# Altium schematic sheet eRatPlatform_1.SchDoc
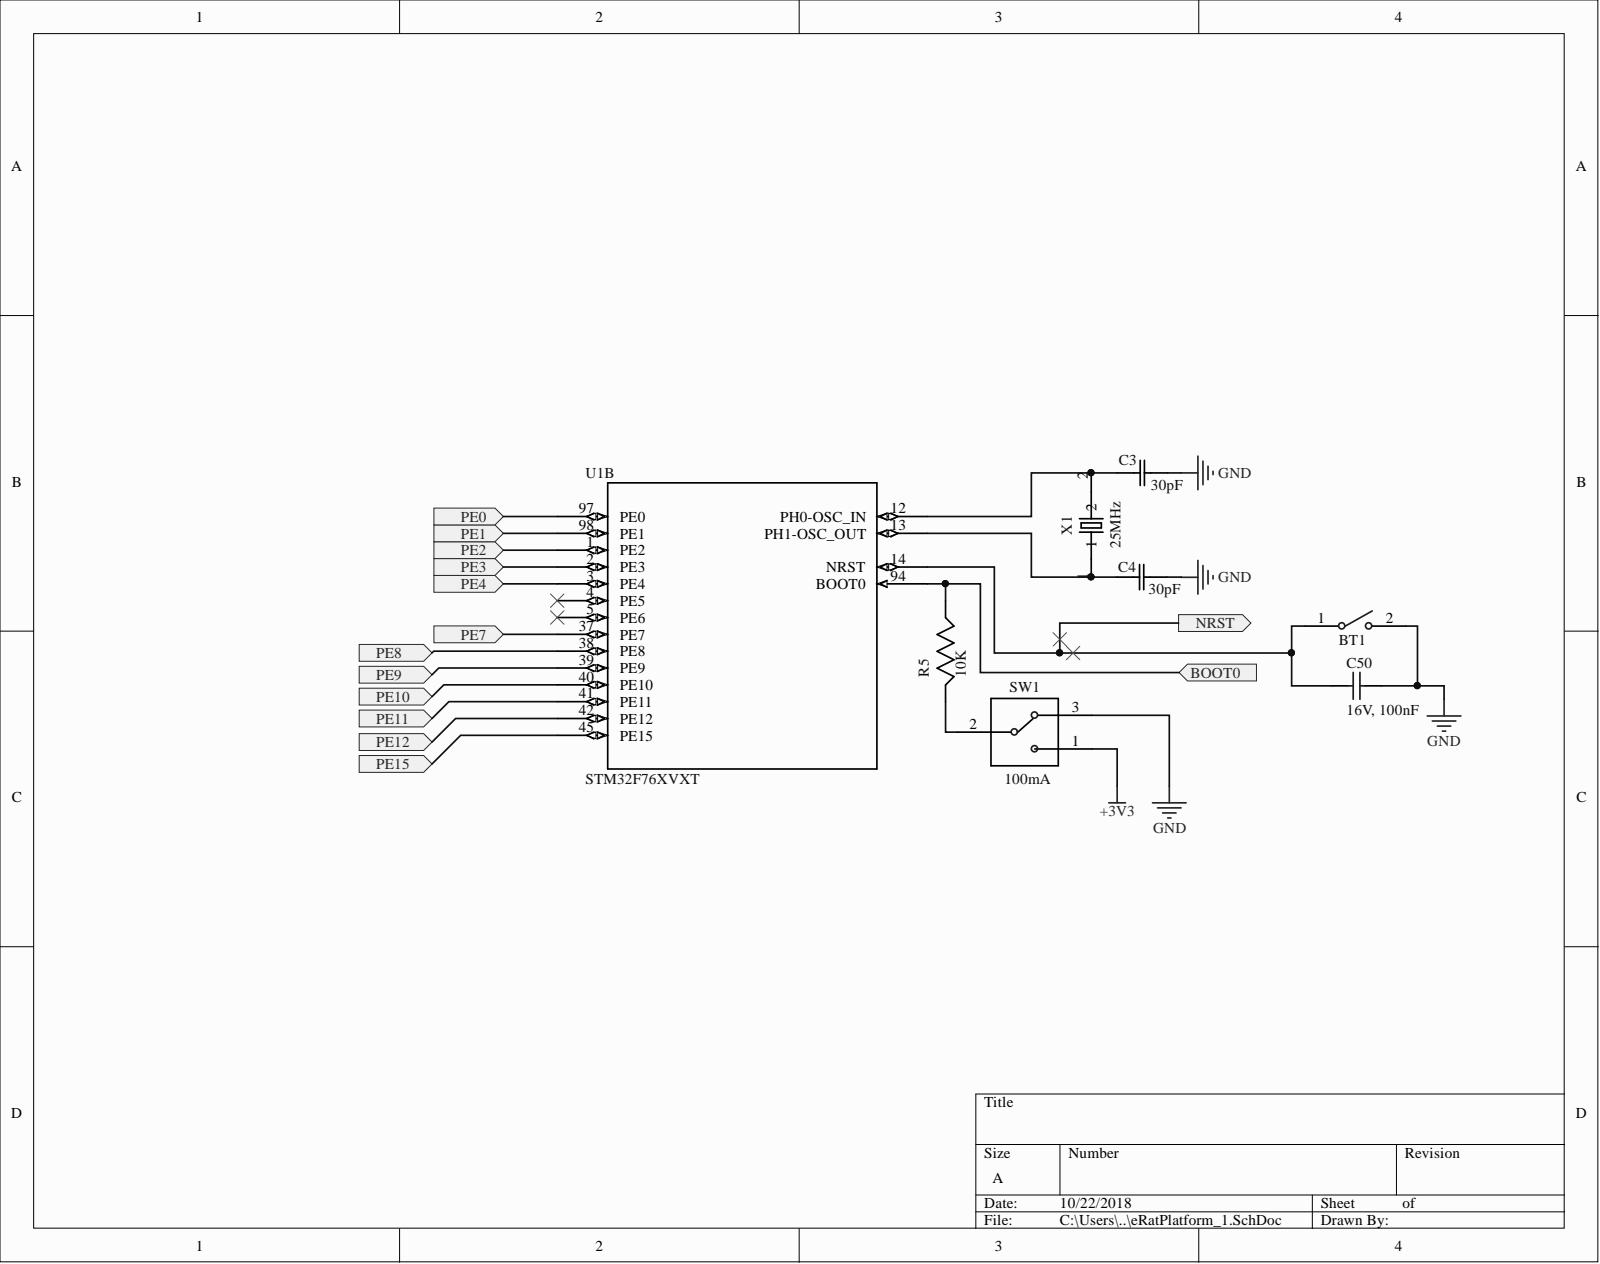
[diagram p0: eRatPlatform_1.SchDoc]

## Parts
BT1  434123050816  [434123050816]  (value not drawn)
C3  30pF  [CAPC1608X85N]
C4  30pF  [CAPC1608X85N]
C50  16V, 100nF  value 100nF  [1206_3.2x1.6x0.8mm]
R5  10K  [RESC1608X55]
SW1  100mA  [SW_CJS-1200TA]
U1  STM32F76XVXT  [LQFP-100_14X14mm]  (part B)
X1  25MHz  [XTAL1170X500X450]

## Ports
BOOT0, NRST, PE0, PE1, PE2, PE3, PE4, PE7, PE8, PE9, PE10, PE11, PE12, PE15

## Nets
+3V3: SW1.1
GND: BT1.2 C3.2 C4.2 C50.2 SW1.3
BOOT0: R5.2 U1.94(BOOT0) ; port BOOT0
NRST: BT1.1 C50.1 U1.14(NRST) ; port NRST
PE0: U1.97(PE0) ; port PE0
PE1: U1.98(PE1) ; port PE1
PE2: U1.1(PE2) ; port PE2
PE3: U1.2(PE3) ; port PE3
PE4: U1.3(PE4) ; port PE4
PE7: U1.37(PE7) ; port PE7
PE8: U1.38(PE8) ; port PE8
PE9: U1.39(PE9) ; port PE9
PE10: U1.40(PE10) ; port PE10
PE11: U1.41(PE11) ; port PE11
PE12: U1.42(PE12) ; port PE12
PE15: U1.45(PE15) ; port PE15
NetC3_1: C3.1 U1.12(PH0-OSC_IN) X1.2
NetC4_1: C4.1 U1.13(PH1-OSC_OUT) X1.1
NetR5_1: R5.1 SW1.2

Not connected: U1 4(PE5) 5(PE6)

## Library data of the parts
BT1 = 434123050816: 6x3.5mm SMD-J-Bend WS-TASV, height 5 mm, 160 gf; Operation Force: 160 gf; Rated Current: 50 mA; Rated Voltage: 12 VDC; Component Kind: Standard; ComponentLink1Description: Manufacturer; ComponentLink1URL: http://www.we-online.de; ComponentLink2Description: Datasheet; ComponentLink2URL: http://katalog.we-online.de/em/datasheet/4341x3xxx8x6.pdf; Library Name: ALTIUM-WS-TASV-rev17b.IntLib; Life Cycle: 50000; Operating Temperature: -40 to +85 degC; Part Number: 434123050816; Published Date: Nov. 2017; Size: 6x3.5x5 mm; Publisher: Wurth Elektronik
C3 C4 = C0603C300J5GACTU: Capacitor: ceramic; MLCC; 30pF; 50V; C0G; Â±5%; SMD; 0603; MF: KEMET; Component Kind: Standard; Digi-Key_Part_Number: 399-9057-1-ND; Digi-Key_Purchase_URL: https://www.digikey.pl/product-detail/en/kemet/C0603C300J5GACTU/399-9057-1-ND/3522574?utm_source=snapeda&utm_medium=aggregator&utm_campaign=symbol; Library Name: C0603C300J5GACTU.IntLib; MP: C0603C300J5GACTU; Package: 0603 KEMET; SnapEDA_Link: https://www.snapeda.com/parts/C0603C300J5GACTU/KEMET/view-part/?ref=snap
C50 = 885012208030: WCAP-CSGP Ceramic Capacitors, 1206, X7R, 16V, 100nF; Rated Voltage: 16V DC; Ceramic Type: X7R; Component Kind: Standard; ComponentLink1Description: Manufacturer; ComponentLink1URL: http://www.we-online.de; ComponentLink2Description: Datasheet; ComponentLink2URL: http://katalog.we-online.de/pbs/datasheet/885012208030.pdf; Library Name: ALTIUM-WCAP-CSGP-1206-rev17b.IntLib; Operating Temperature: -55 °C to +125 °C; Part Number: 885012208030; Published Date: Oct. 2017; Size: 1206; Publisher: Wurth Elektronik
R5 = ERJ-3EKF1002V: Res Thick Film 0603 10K Ohm 1% 0.1W_1/10W_ Ã‚Â±100ppm/Ã‚Â°C Molded SMD Automotive Punched T/R; MF: Panasonic; Component Kind: Standard; Digi-Key_Part_Number: P10.0KHCT-ND; Digi-Key_Purchase_URL: https://www.digikey.pl/product-detail/en/panasonic-electronic-components/ERJ-3EKF1002V/P10.0KHCT-ND/198102?utm_source=snapeda&utm_medium=aggregator&utm_campaign=symbol; Library Name: ERJ-3EKF1002V.IntLib; MP: ERJ-3EKF1002V; Package: 0603 Panasonic; SnapEDA_Link: https://www.snapeda.com/parts/ERJ-3EKF1002V/Panasonic%20Electronic%20Components/view-part/?ref=snap
SW1 = CJS-1200TA: Switch Slide N.O./N.C. SPDT Flush Slide 0.1A 24VDC J-Hook SMD T/R; MF: Nidec Copal; Component Kind: Standard; Digi-Key_Part_Number: 563-1021-1-ND; Digi-Key_Purchase_URL: https://www.digikey.pl/product-detail/en/nidec-copal-electronics/CJS-1200TA/563-1021-1-ND/948401?utm_source=snapeda&utm_medium=aggregator&utm_campaign=symbol; Library Name: CJS-1200TA.IntLib; MP: CJS-1200TA; Package: None; SnapEDA_Link: https://www.snapeda.com/parts/CJS-1200TA/Nidec%20Copal/view-part/?ref=snap
U1 = STM32F76XVXT: Imported; C: Copyright (C) 2016 Accelerated Designs. All rights reserved; Vendor: STMicroelectronics; Component Kind: Standard; Library Name: STM32F767VIT6.IntLib; Manufacturer_Part_Number: stm32f76xvxt; RefDes: RefDes; Type: Type; Value: Value; Copyright: Copyright (C) 2016 Accelerated Designs. All rights reserved
X1 = FOXSDLF/250F-20: HC49SDLF Series 25 MHz Â±30 ppm 20 pF -20 to +70 Â°C SMT Resistance Weld Crystal; MF: Fox Electronics; MP: FOXSDLF/250F-20/TR; Availability: Good; Component Kind: Standard; Library Name: FOXSDLF_250F-20.IntLib; Package: None; Price: 0.29 USD; SnapEDA_Link: https://www.snapeda.com/parts/FOXSDLF/250F-20/TR/Fox%20Electronics/view-part/?ref=snap
